# Altium schematic sheet REGULATOR.SchDoc(REGULATOR)
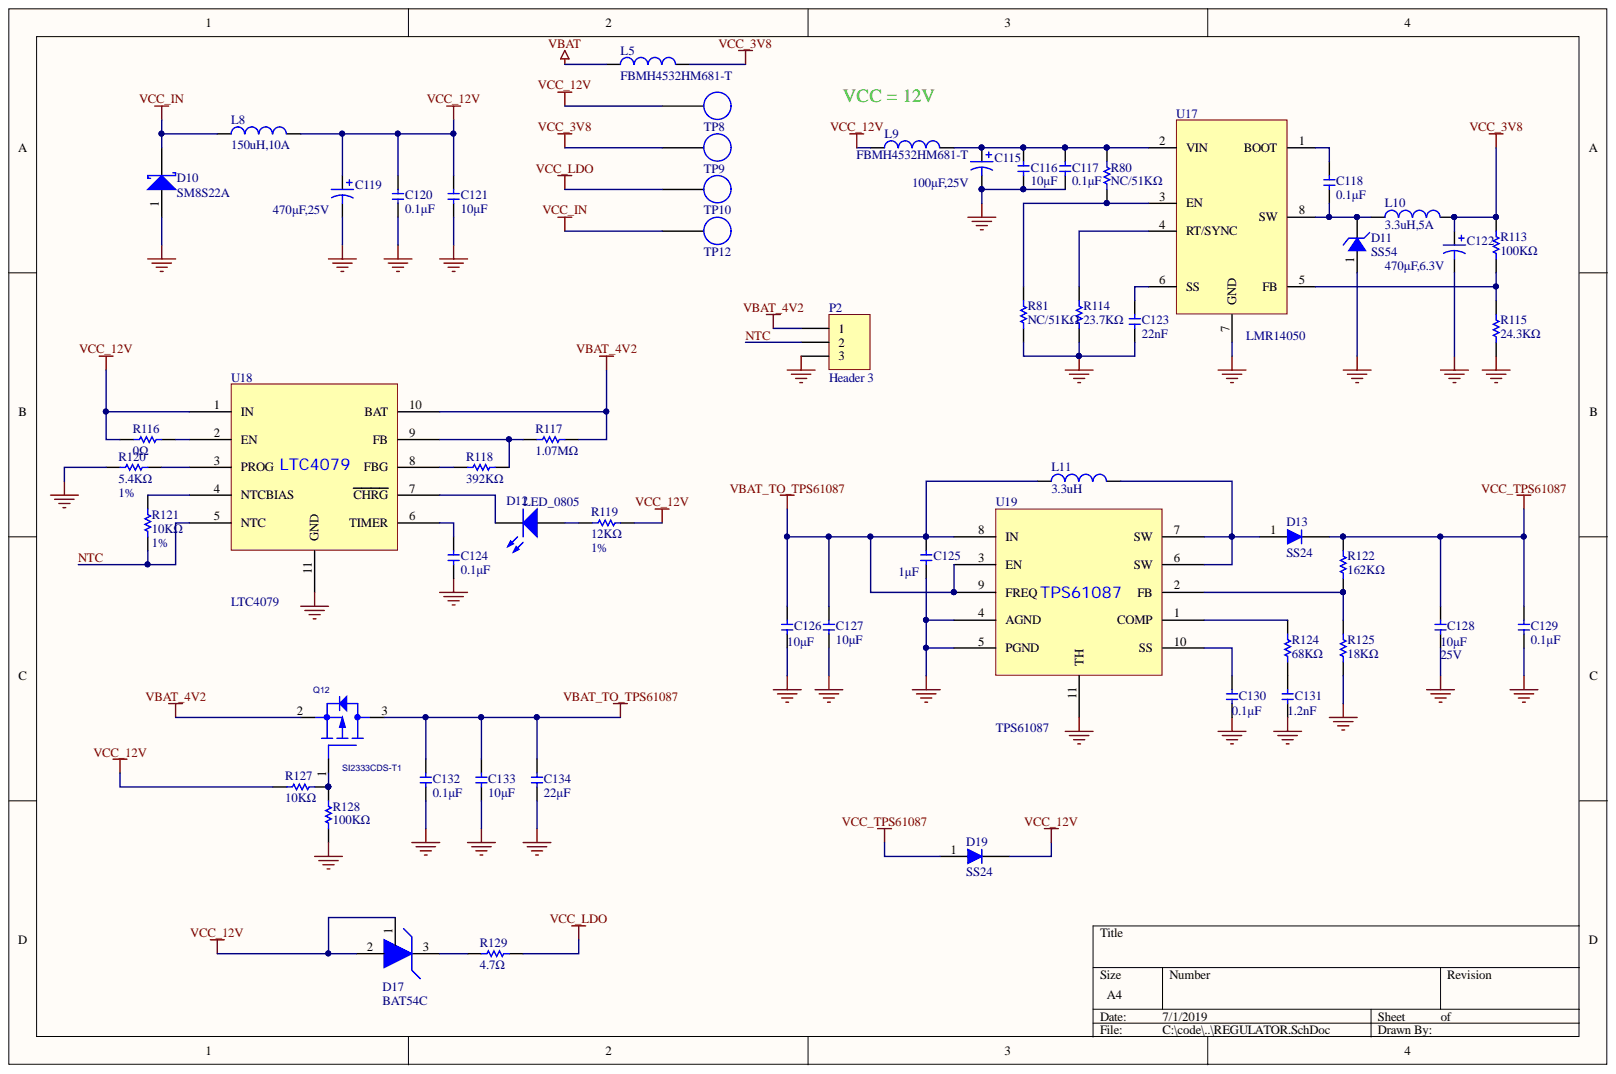
[diagram p0: REGULATOR.SchDoc]

## Parts
C115  100μF,25V  [CAPAE-D63*54]
C116  10μF  [C0805]
C117  0.1μF  [C0402]
C118  0.1μF  [C0402]
C119  470μF,25V  [CAPAE-D10*10.2]
C120  0.1μF  [C0402]
C121  10μF  [C0805]
C122  470μF,6.3V  [CAPAE-D63*54]
C123  22nF  [C0402]
C124  0.1μF  [C0402]
C125  1μF  [C0402]
C126  10μF  [C0805]
C127  10μF  [C0805]
C128  10μF  value1: 25V  [C0805]
C129  0.1μF  [C0402]
C130  0.1μF  [C0402]
C131  1.2nF  [C0402]
C132  0.1μF  [C0402]
C133  10μF  [C0805]
C134  22μF  [C0402]
D10  SM8S22A  [DO-218AB]
D11  SS54  [SMC]
D12  LED_0805  [LED_0805]
D13  SS24  [SMA]
D17  BAT54C  [SOT23_L]
D19  SS24  [SMA]
L5  FBMH4532HM681-T  [L-1812]
L8  150uH,10A  [IND_20mm×9.5mm]
L9  FBMH4532HM681-T  [L-1812]
L10  3.3uH,5A  [L-0420]
L11  3.3uH  [L-0420]
P2  Header 3  [HDR1X3]
Q12  SI2333CDS-T1  [SOT23_L]
R80  NC/51KΩ  [R0402]
R81  NC/51KΩ  [R0402]
R113  100KΩ  [R0402]
R114  23.7KΩ  [R0402]
R115  24.3KΩ  [R0402]
R116  0Ω  [R0603]
R117  1.07MΩ  [R0603]
R118  value 392KΩ  [R0603]  (hidden comment: 412KΩ)
R119  12KΩ  value1: 1%  [R0603]
R120  value 5.4KΩ  [R0402]  (hidden comment: 3.01KΩ)
R121  10KΩ  value1: 1%  [R0402]
R122  162KΩ  [R0402]
R124  68KΩ  [R0402]
R125  18KΩ  [R0402]
R127  10KΩ  [R0402]
R128  10KΩ  value 100KΩ  [R0402]
R129  4.7Ω  [R1206]
TP8  TestPoint  [TP]  (value not drawn)
TP9  TestPoint  [TP]  (value not drawn)
TP10  TestPoint  [TP]  (value not drawn)
TP12  TestPoint  [TP]  (value not drawn)
U17  LMR14050  [SOIC-8_N]
U18  LTC4079  [DFN_10_LTC4079]
U19  TPS61087  [VSON-10]

## Nets
GND: C115.2 C116.1 C117.1 C119.2 C120.1 C121.1 C122.2 C123.1 C124.1 C125.1 C126.1 C127.1 C128.1 C129.1 C130.1 C131.1 C132.1 C133.1 C134.1 D10.1 D11.1 P2.3 R81.2 R114.2 R115.2 R120.1 R125.2 R128.1 U17.7(GND) U18.11(GND) U19.4(AGND) U19.5(PGND) U19.11(TH)
VBAT: L5.2
VBAT_4V2: P2.1 Q12.2 R117.1 U18.10(BAT)
VBAT_TO_TPS61087: C125.2 C126.2 C127.2 C132.2 C133.2 C134.2 L11.1 Q12.3 U19.3(EN) U19.8(IN) U19.9(FREQ)
VCC_3V8: C122.1 L5.1 L10.2 R113.1 TP9.1
VCC_12V: C119.1 C120.2 C121.2 D17.1 D17.2 D19.2 L8.1 L9.1 R116.1 R119.2 R127.2 TP8.1 U18.1(IN)
VCC_IN: D10.2 L8.2 TP12.1
VCC_LDO: R129.1 TP10.1
VCC_TPS61087: C128.2 C129.2 D13.2 D19.1 R122.1
NTC: P2.2 R121.2 U18.5(NTC)
NetC115_1: C115.1 C116.2 C117.2 L9.2 R80.1 U17.2(VIN)
NetC118_1: C118.1 D11.2 L10.1 U17.8(SW)
NetC118_2: C118.2 U17.1(BOOT)
NetC123_2: C123.2 U17.6(SS)
NetC124_2: C124.2 U18.6(TIMER)
NetC130_2: C130.2 U19.10(SS)
NetC131_2: C131.2 R124.2
NetD12_1: D12.1 R119.1
NetD12_2: D12.2 U18.7(CHRG)
NetD13_1: D13.1 L11.2 U19.6(SW) U19.7(SW)
NetD17_3: D17.3 R129.2
NetQ12_1: Q12.1 R127.1 R128.2
NetR80_2: R80.2 R81.1 U17.3(EN)
NetR113_2: R113.2 R115.1 U17.5(FB)
NetR114_1: R114.1 U17.4(RT/SYNC)
NetR116_2: R116.2 U18.2(EN)
NetR117_2: R117.2 R118.1 U18.9(FB)
NetR118_2: R118.2 U18.8(FBG)
NetR120_2: R120.2 U18.3(PROG)
NetR121_1: R121.1 U18.4(NTCBIAS)
NetR122_2: R122.2 R125.1 U19.2(FB)
NetR124_1: R124.1 U19.1(COMP)

## Library data of the parts
C115 C119 C122 = Cap Pol2: Polarized Capacitor (Axial); Simulation: CAP; Signal Integrity: Cap Pol; Published: 8-Jun-2000; PackageReference: POLAR0.8; PackageDescription: Polarized Capacitor; 2 Leads; Library Name: Miscellaneous Devices.SchLib; LatestRevisionNote: Re-released for DXP Platform.; LatestRevisionDate: 17-Jul-2002; Component Kind: Standard; Publisher: Altium Limited
  C115: Value: 100μF,25V
  C119: Value: 470μF,25V
  C122: Value: 470μF,6.3V
C116-C118 C120 C121 C123-C134 = Cap Semi: Capacitor (Semiconductor SIM Model); Simulation: CAP; Signal Integrity: Cap Semi; Published: 8-Jun-2000; PackageReference: C1206; PackageDescription: Chip Capacitor; Library Name: Miscellaneous Devices.SchLib; LatestRevisionNote: IPC-7351 Footprint Added.; LatestRevisionDate: 29-May-2009; Component Kind: Standard; Publisher: Altium Limited
  C116 C121 C126-C128 C133: Value: 10μF
  C117 C118 C120 C124 C129 C130 C132: Value: 0.1μF
  C123: Value: 22nF
  C125: Value: 1μF
  C131: Value: 1.2nF
  C134: Value: 22μF
D10 = D Schottky: Schottky Diode; Simulation: SKYDIODE; Signal Integrity: D Schottky; Published: 8-Jun-2000; PackageReference: SMB; PackageDescription: DO-214-AA/SMB; 2 C-Bend Leads; Body 5.3 x 3.6 mm, inc. leads (LxW); Library Name: Miscellaneous Devices.SchLib; LatestRevisionNote: Re-released for DXP Platform.; LatestRevisionDate: 17-Jul-2002; Component Kind: Standard; Code_JEDEC: DO-214-AA; Publisher: Altium Limited
D11 = D Zener: Zener Diode; Simulation: ZENER; Signal Integrity: D Zener; Published: 8-Jun-2000; PackageReference: DIODE-0.7; PackageDescription: Diode, Thru-Hole; 2 Leads; 0.7 in Pin Spacing; Library Name: Miscellaneous Devices.SchLib; LatestRevisionNote: Re-released for DXP Platform.; LatestRevisionDate: 17-Jul-2002; Component Kind: Standard; Publisher: Altium Limited
D12 = LED0: Typical INFRARED GaAs LED; Simulation: LED0; Published: 8-Jun-2000; PackageReference: LED-0; PackageDescription: LED; 2 Leads; Library Name: Miscellaneous Devices.SchLib; LatestRevisionNote: Mech Layer 1 removed.; LatestRevisionDate: 08-Jul-2005; Component Kind: Standard; Publisher: Altium Limited
D13 D19 = Diode: Default Diode; Simulation: DIODE; Signal Integrity: Diode; Published: 8-Jun-2000; PackageVersion: Sep-1996; PackageReference: SMC; PackageDescription: DO-214-AB/SMC; 2 C-Bend Leads; Body 7.9 x 5.9 mm, inc. leads (LxW); Library Name: Miscellaneous Devices.SchLib; LatestRevisionNote: Re-released for DXP Platform.; LatestRevisionDate: 17-Jul-2002; Component Kind: Standard; Code_JEDEC: DO-214-AB; Publisher: Altium Limited
D17 = D Zener_1: Zener Diode; Simulation: ZENER; Signal Integrity: D Zener; Published: 8-Jun-2000; PackageReference: DIODE-0.7; PackageDescription: Diode, Thru-Hole; 2 Leads; 0.7 in Pin Spacing; Library Name: Miscellaneous Devices.SchLib; LatestRevisionNote: Re-released for DXP Platform.; LatestRevisionDate: 17-Jul-2002; Component Kind: Standard; Publisher: Altium Limited
L5 L9 = Inductor: Value: 100MHz,680Ω,4A; Code_IEC: 1005; Simulation: INDUCTOR; Signal Integrity: Inductor; Published: 8-Jun-2000; PackageReference: 0402-A; PackageDescription: Chip Inductor; Library Name: Miscellaneous Devices.SchLib; LatestRevisionNote: IPC-7351 Footprint Added.; LatestRevisionDate: 29-May-2009; Description: Inductor; Component Kind: Standard; Code_JEITA: 0402; Publisher: Altium Limited
L8 L10 L11 = Inductor: Code_IEC: 1005; Simulation: INDUCTOR; Signal Integrity: Inductor; Published: 8-Jun-2000; PackageReference: 0402-A; PackageDescription: Chip Inductor; Library Name: Miscellaneous Devices.SchLib; LatestRevisionNote: IPC-7351 Footprint Added.; LatestRevisionDate: 29-May-2009; Description: Inductor; Component Kind: Standard; Code_JEITA: 0402; Publisher: Altium Limited
  L8: Value: 150uH,10A
  L10: Value: 3.3uH,5A
  L11: Value: 3.3uH
P2 = Header 3: Header, 3-Pin; Signal Integrity: Connector; Library Name: Miscellaneous Connectors.IntLib; LatestRevisionNote: Re-released for DXP Platform.; LatestRevisionDate: 17-Jul-2002; Component Kind: Standard; Publisher: Altium Limited
Q12 = PMOS: P-Channel 12-V (D-S) MOSFET; Library Name: Miscellaneous Devices.SchLib; Component Kind: Standard
R80 R81 R113-R115 R119 R121 R122 R124 R125 R127 R128 = Res1: Resistor; Simulation: RESISTOR; Signal Integrity: Res; Published: 8-Jun-2000; PackageReference: AXIAL-0.3; PackageDescription: Axial Device, Thru-Hole; 2 Leads; 0.3 in Pin Spacing; Library Name: Miscellaneous Devices.SchLib; LatestRevisionNote: Re-released for DXP Platform.; LatestRevisionDate: 17-Jul-2002; Component Kind: Standard; Publisher: Altium Limited
  R80 R81: Value: NC/51KΩ
  R113: Value: 100KΩ
  R114: Value: 23.7KΩ
  R115: Value: 24.3KΩ
  R119: Value: 12KΩ
  R121 R127: Value: 10KΩ
  R122: Value: 162KΩ
  R124: Value: 68KΩ
  R125: Value: 18KΩ
R116-R118 R120 R129 = Res1: Resistor; value1: 1%; Simulation: RESISTOR; Signal Integrity: Res; Published: 8-Jun-2000; PackageReference: AXIAL-0.3; PackageDescription: Axial Device, Thru-Hole; 2 Leads; 0.3 in Pin Spacing; Library Name: Miscellaneous Devices.SchLib; LatestRevisionNote: Re-released for DXP Platform.; LatestRevisionDate: 17-Jul-2002; Component Kind: Standard; Publisher: Altium Limited
  R116: Value: 0Ω
  R117: Value: 1.07MΩ
  R129: Value: 4.7Ω
U17 = LMR14050: SIMPLE SWITCHER® 40 V 5 A, 2.2 MHz Step-Down Converter with 40 μA IQ; Simulation: PLLx; Published: 8-Jun-2000; PackageVersion: May-1999; PackageReference: DB008; PackageDescription: 8-Pin Plastic Small Outline Package 0.65 mm Pitch; Library Name: Miscellaneous Devices.SchLib; LatestRevisionNote: IPC-7351 Footprint Added.; LatestRevisionDate: 29-May-2009; Component Kind: Standard; Code_JEDEC: MO-150-AA; Code_IPC: SOP65P780-8; Publisher: Altium Limited
U18 = LTC4079: 60V, 250mA Linear Charger with Low Quiescent Current; Library Name: Miscellaneous Devices.SchLib; Component Kind: Standard
U19 = TPS61087: 650-kHz,1.2-MHz, 18.5-V Step-Up DC-DC Converter With 3.2-A Switch; Library Name: Miscellaneous Devices.SchLib; Component Kind: Standard
TP8-TP10 TP12 = TestPoint: Library Name: Miscellaneous Devices.SchLib; Component Kind: Standard
